# Altium schematic sheet PlanTieV2.SchDoc
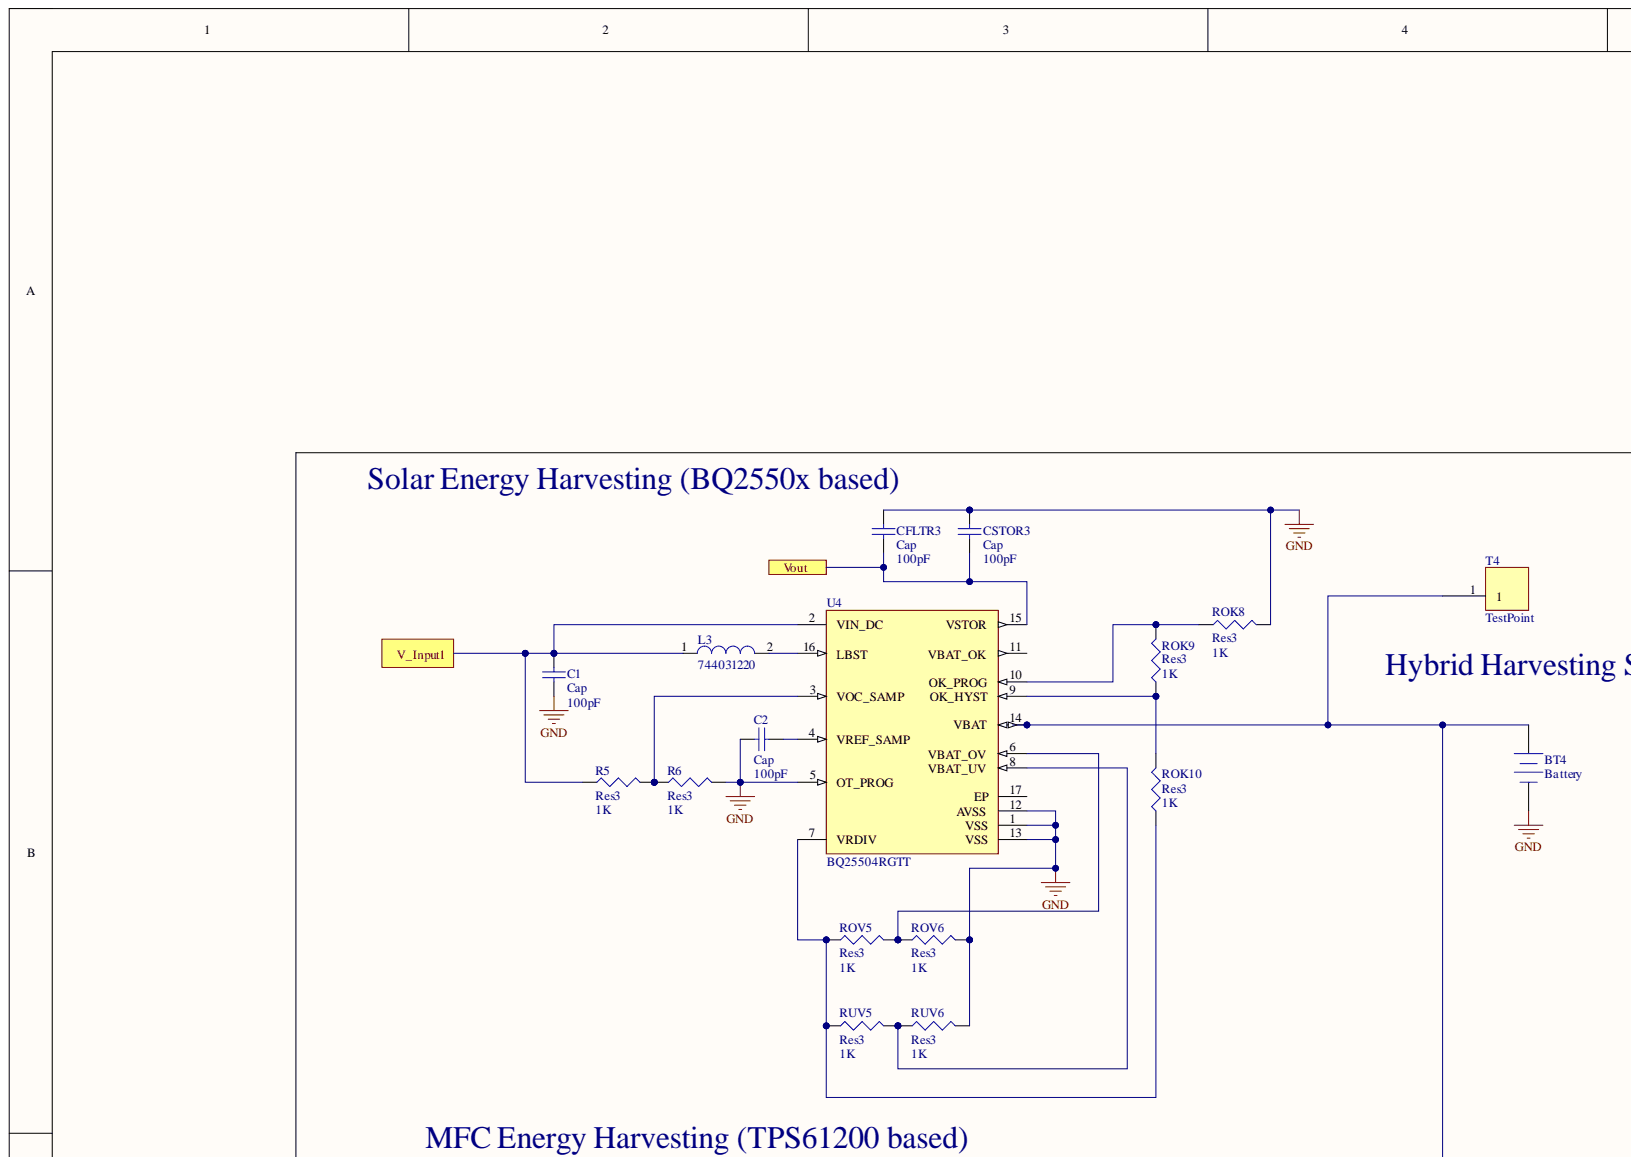
[diagram p0: PlanTieV2.SchDoc, top left]
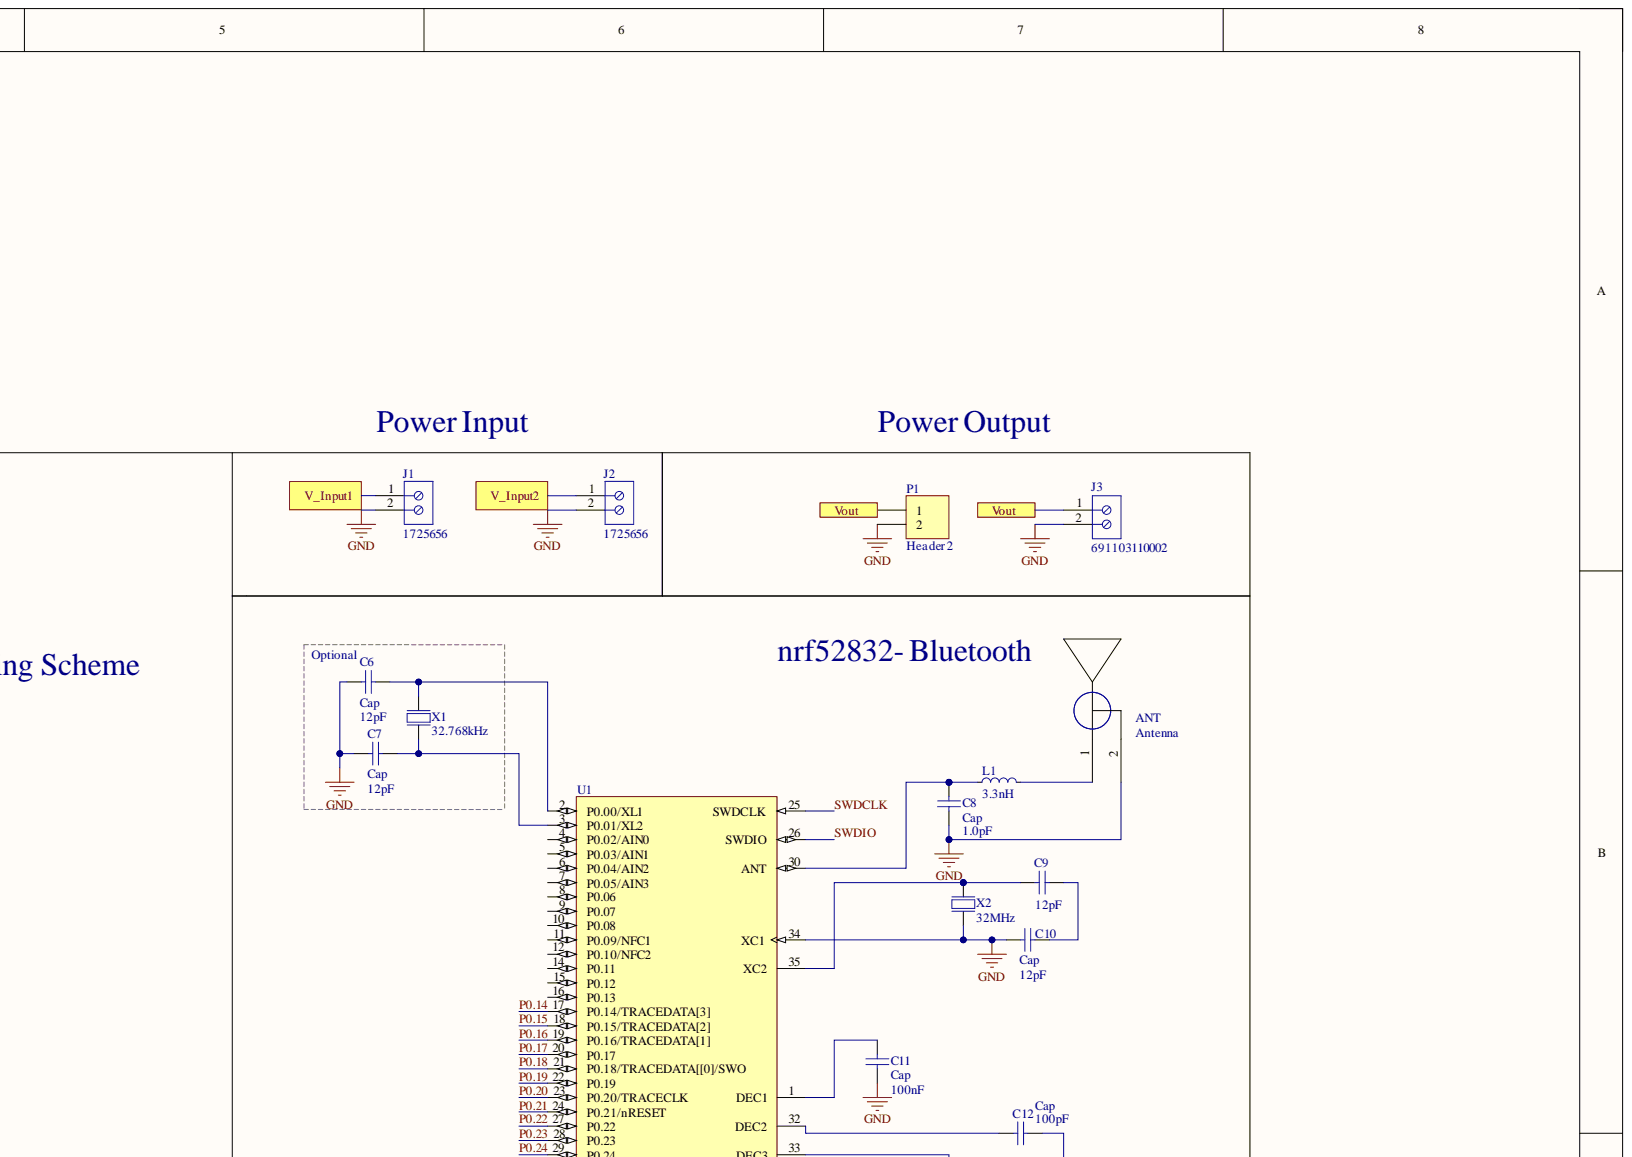
[diagram p1: PlanTieV2.SchDoc, top right]
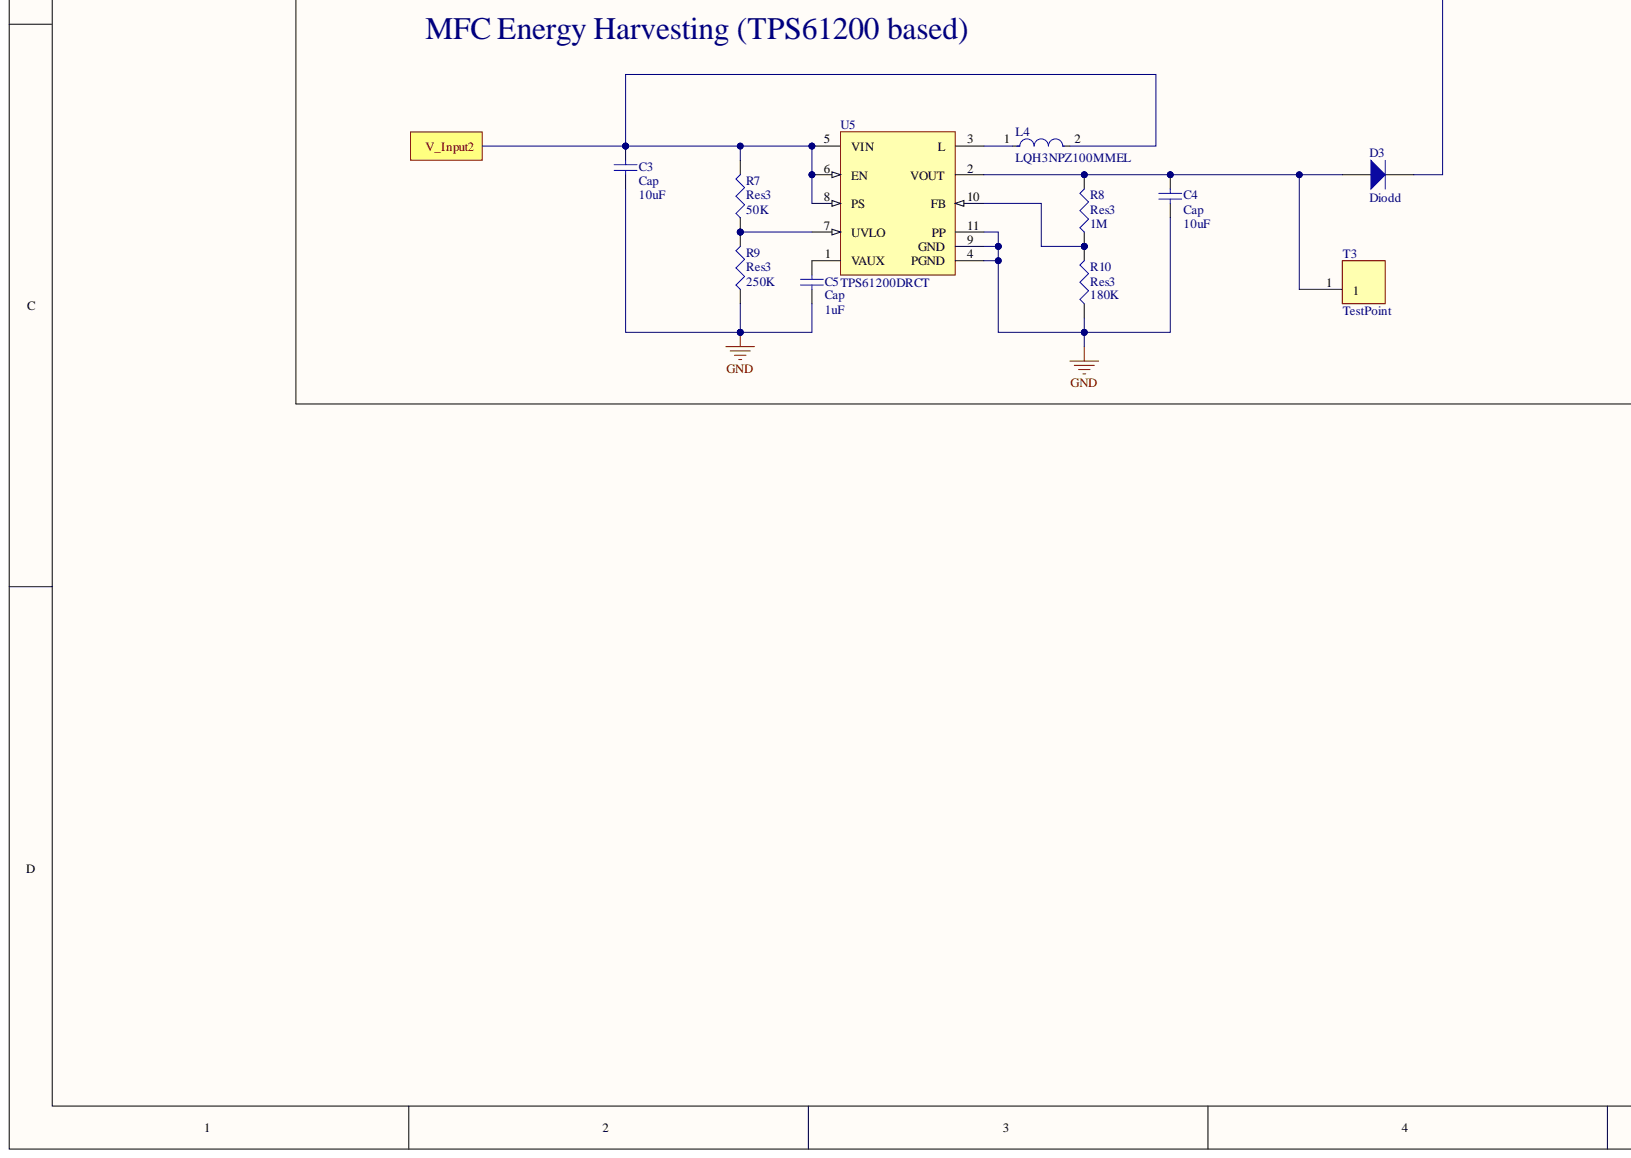
[diagram p2: PlanTieV2.SchDoc, bottom left]
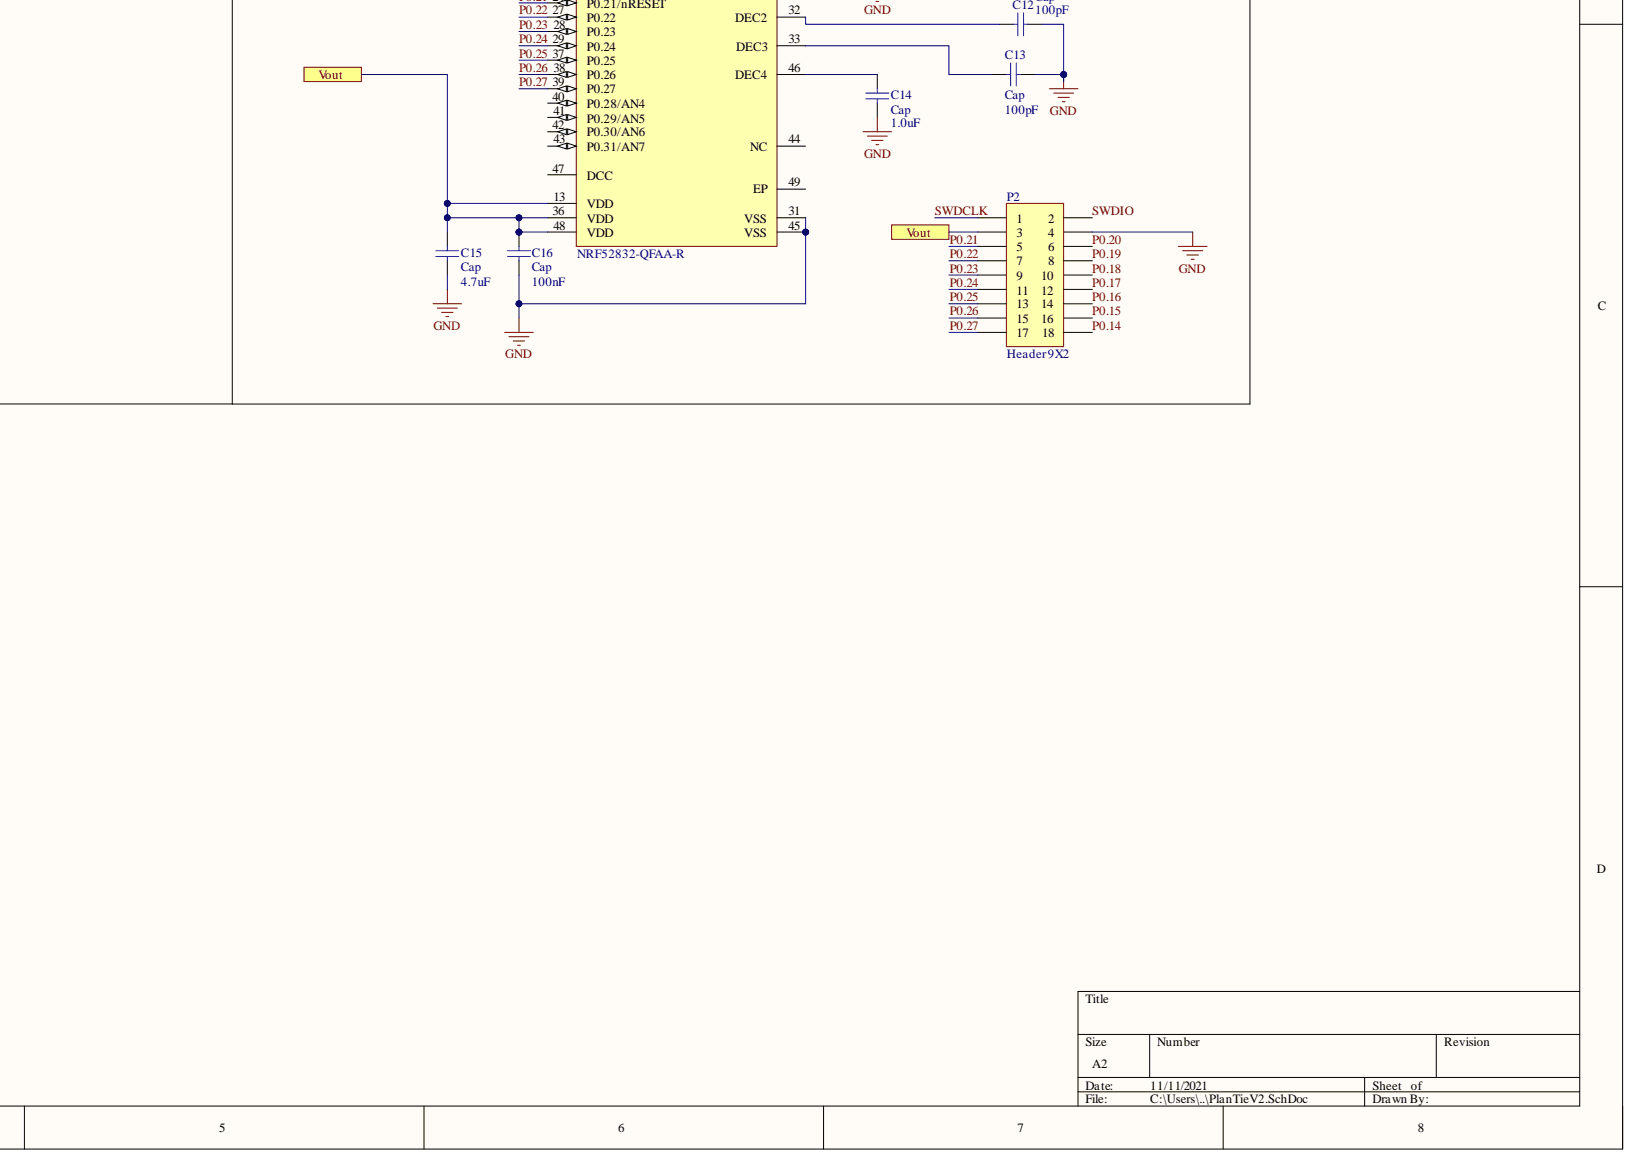
[diagram p3: PlanTieV2.SchDoc, bottom right]

## Parts
ANT  Antenna  [BT-AFN]
BT4  Battery  [BAT-2]
C1  Cap  value 100pF  [1608[0603]]
C2  Cap  value 100pF  [1608[0603]]
C3  Cap  value 10uF  [1608[0603]]
C4  Cap  value 10uF  [1608[0603]]
C5  Cap  value 1uF  [1608[0603]]
C6  Cap  value 12pF  [1608[0603]]
C7  Cap  value 12pF  [1608[0603]]
C8  Cap  value 1.0pF  [1608[0603]]
C9  Cap  value 12pF  [1608[0603]]
C10  Cap  value 12pF  [1608[0603]]
C11  Cap  value 100nF  [1608[0603]]
C12  Cap  value 100pF  [1608[0603]]
C13  Cap  value 100pF  [1608[0603]]
C14  Cap  value 1.0uF  [1608[0603]]
C15  Cap  value 4.7uF  [1608[0603]]
C16  Cap  value 100nF  [1608[0603]]
CFLTR3  Cap  value 100pF  [1608[0603]]
CSTOR3  Cap  value 100pF  [1608[0603]]
D3  Diodd  [DO-41]
J1  1725656  [PHOE-1725656-2]
J2  1725656  [PHOE-1725656-2]
J3  691103110002  [691103110002]
L1  3.3nH  [INDC0603X03L]
L3  744031220  [WE-TPC-3816-S]
L4  LQH3NPZ100MMEL  [FP-LQH3NPH_ME-MFG]
P1  Header 2  [HDR1X2]
P2  Header 9X2  [HDR2X9]
R5  Res3  value 1K  [J1-0603]
R6  Res3  value 1K  [J1-0603]
R7  Res3  value 50K  [J1-0603]
R8  Res3  value 1M  [J1-0603]
R9  Res3  value 250K  [J1-0603]
R10  Res3  value 180K  [J1-0603]
ROK8  Res3  value 1K  [J1-0603]
ROK9  Res3  value 1K  [J1-0603]
ROK10  Res3  value 1K  [J1-0603]
ROV5  Res3  value 1K  [J1-0603]
ROV6  Res3  value 1K  [J1-0603]
RUV5  Res3  value 1K  [J1-0603]
RUV6  Res3  value 1K  [J1-0603]
T3  TestPoint  [PIN1]
T4  TestPoint  [PIN1]
U1  NRF52832-QFAA-R  [NRSC-QF-48_M]
U4  BQ25504RGTT  [RGT0016C_V]
U5  TPS61200DRCT  [DRC0010J_V]
X1  32.768kHz  [XTAL_2012]
X2  32MHz  [BT-XTAL_2016]

## Ports
V_Input1, V_Input2, Vout

## Nets
GND: ANT.2 BT4.2 C1.1 C2.1 C3.1 C4.1 C5.1 C6.2 C7.2 C8.2 C10.2 C11.2 C12.2 C13.1 C14.2 C15.2 C16.2 CFLTR3.2 CSTOR3.2 J1.2 J2.2 J3.2 P1.2 P2.4 R6.2 R9.1 R10.1 ROK8.2 ROV6.2 RUV6.2 U1.31(VSS) U1.34(XC1) U1.45(VSS) U4.1(VSS) U4.5(OT_PROG) U4.12(AVSS) U4.13(VSS) U5.4(PGND) U5.9(GND) U5.11(PP) X2.1 X2.2 X2.4
P0.14: P2.18 U1.17(P0.14/TRACEDATA[3])
P0.15: P2.16 U1.18(P0.15/TRACEDATA[2])
P0.16: P2.14 U1.19(P0.16/TRACEDATA[1])
P0.17: P2.12 U1.20(P0.17)
P0.18: P2.10 U1.21(P0.18/TRACEDATA[[0]/SWO)
P0.19: P2.8 U1.22(P0.19)
P0.20: P2.6 U1.23(P0.20/TRACECLK)
P0.21: P2.5 U1.24(P0.21/nRESET)
P0.22: P2.7 U1.27(P0.22)
P0.23: P2.9 U1.28(P0.23)
P0.24: P2.11 U1.29(P0.24)
P0.25: P2.13 U1.37(P0.25)
P0.26: P2.15 U1.38(P0.26)
P0.27: P2.17 U1.39(P0.27)
SWDCLK: P2.1 U1.25(SWDCLK)
SWDIO: P2.2 U1.26(SWDIO)
V_Input1: C1.2 J1.1 L3.1 R5.1 U4.2(VIN_DC) ; port V_Input1
V_Input2: C3.2 J2.1 L4.2 R7.2 U5.5(VIN) U5.6(EN) U5.8(PS) ; port V_Input2
Vout: C15.1 C16.1 CFLTR3.1 CSTOR3.1 J3.1 P1.1 P2.3 U1.13(VDD) U1.36(VDD) U1.48(VDD) U4.15(VSTOR) ; port Vout
NetANT_1: ANT.1 L1.2
NetBT4_1: BT4.1 D3.2 T4.1 U4.14(VBAT)
NetC2_2: C2.2 U4.4(VREF_SAMP)
NetC4_2: C4.2 D3.1 R8.2 T3.1 U5.2(VOUT)
NetC5_2: C5.2 U5.1(VAUX)
NetC6_1: C6.1 U1.2(P0.00/XL1) X1.1
NetC7_1: C7.1 U1.3(P0.01/XL2) X1.2
NetC8_1: C8.1 L1.1 U1.30(ANT)
NetC9_1: C9.1 C10.1
NetC9_2: C9.2 U1.35(XC2) X2.3
NetC11_1: C11.1 U1.1(DEC1)
NetC12_1: C12.1 U1.32(DEC2)
NetC13_2: C13.2 U1.33(DEC3)
NetC14_1: C14.1 U1.46(DEC4)
NetL3_2: L3.2 U4.16(LBST)
NetL4_1: L4.1 U5.3(L)
NetR5_2: R5.2 R6.1 U4.3(VOC_SAMP)
NetR7_1: R7.1 R9.2 U5.7(UVLO)
NetR8_1: R8.1 R10.2 U5.10(FB)
NetROK8_1: ROK8.1 ROK9.2 U4.10(OK_PROG)
NetROK9_1: ROK9.1 ROK10.2 U4.9(OK_HYST)
NetROK10_1: ROK10.1 ROV5.1 RUV5.1 U4.7(VRDIV)
NetROV5_2: ROV5.2 ROV6.1 U4.6(VBAT_OV)
NetRUV5_2: RUV5.2 RUV6.1 U4.8(VBAT_UV)

Not connected: U1 4(P0.02/AIN0) 5(P0.03/AIN1) 6(P0.04/AIN2) 7(P0.05/AIN3) 8(P0.06) 9(P0.07) 10(P0.08) 11(P0.09/NFC1) 12(P0.10/NFC2) 14(P0.11) 15(P0.12) 16(P0.13) 40(P0.28/AN4) 41(P0.29/AN5) 42(P0.30/AN6) 43(P0.31/AN7) 44(NC) 47(DCC) 49(EP); U4 11(VBAT_OK) 17(EP)

## Library data of the parts
BT4 = Battery: Multicell Battery; Component Kind: Standard; LatestRevisionDate: 17-Jul-2002; LatestRevisionNote: Re-released for DXP Platform.; Library Name: PPi_Hardware.SCHLIB; PackageDescription: Battery; 2 Leads; PackageReference: BAT-2; Published: 24-Mar-1999; Publisher: Altium Limited
C1 C3-C5 C7-C16 CFLTR3 CSTOR3 = Cap: Capacitor; Component Kind: Standard; LatestRevisionDate: 17-Jul-2002; LatestRevisionNote: Re-released for DXP Platform.; Library Name: Miscellaneous Devices.IntLib; PackageDescription: Radial Cap, Thru-Hole; 2 Leads; 0.3 in Pin Spacing; PackageReference: RAD-0.3; Published: 8-Jun-2000; Signal Integrity: Cap; Simulation: CAP; Publisher: Altium Limited
C2 = Cap: Capacitor; Value: 100pF; Component Kind: Standard; LatestRevisionDate: 17-Jul-2002; LatestRevisionNote: Re-released for DXP Platform.; Library Name: Miscellaneous Devices.IntLib; PackageDescription: Radial Cap, Thru-Hole; 2 Leads; 0.3 in Pin Spacing; PackageReference: RAD-0.3; Published: 8-Jun-2000; Signal Integrity: Cap; Simulation: CAP; Publisher: Altium Limited
C6 = Cap: Capacitor; Value: 12pF; Component Kind: Standard; LatestRevisionDate: 17-Jul-2002; LatestRevisionNote: Re-released for DXP Platform.; Library Name: Miscellaneous Devices.IntLib; PackageDescription: Radial Cap, Thru-Hole; 2 Leads; 0.3 in Pin Spacing; PackageReference: RAD-0.3; Published: 8-Jun-2000; Signal Integrity: Cap; Simulation: CAP; Publisher: Altium Limited
D3 = Diode 1N4934: 1 Amp Fast Recovery Rectifier; Code_JEDEC: DO-204-AL; Component Kind: Standard; ComponentLink1Description: Manufacturer Link; ComponentLink1URL: http://www.fairchildsemi.com/; ComponentLink2Description: Datasheet; ComponentLink2URL: http://www.fairchildsemi.com/ds/1N%2F1N4934.pdf; DatasheetVersion: 1999; LatestRevisionDate: 17-Jul-2002; LatestRevisionNote: Re-released for DXP Platform.; Library Name: Miscellaneous Devices.IntLib; PackageDescription: Diode, Axial; Body 5.2 x 2.7 mm (LxDia. max), Lead Dia. 0.86 mm (max); PackageReference: DO-41; PackageVersion: Aug-1999; Published: 8-Jun-2000; Signal Integrity: 1N4934; Simulation: 1N4934; Publisher: Altium Limited
J1 J2 = CMP-2000-05299-1: Male Header, Pitch 2.54 mm, 1 x 2 Position, Height 9 mm, Tail Length 3.5 mm; Manufacturer: Phoenix Contact; Content: 250pc(s); Industrial packaging: Yes; Number of contacts: 2; Pitch: 2.54mm; Colour: Green; Component Kind: Standard; Datasheet URL: http://datasheet.octopart.com/1725656-Phoenix-Contact-datasheet-5302866.pdf; Datasheet Version: Apr-08; Library Name: Altium Content Vault; Manufacturer part No.: 1725656; Manufacturer URL: http://www.phoenixcontact.com/; Type: MPT0,5/2-2,54
J3 = CMP-1502-03254-1: Series 1031 - 3.50 mm Horizontal Entry Modular with Pressure Clamp WR-TBL, 2 pin; Manufacturer: Wurth Elektronik; Pin Number: 2; Pitch: 3.50mm; Component Kind: Standard; Datasheet URL: http://katalog.we-online.de/em/datasheet/6911031100xx.pdf; Library Name: Altium Content Vault; Manufacturer URL: http://www.we-online.de; Mounting Technology: THT; Operating Temperature: -40to105degC; Package Reference: 691103110002; Packaging: Box; Part Number: 691103110002; Publisher: WurthElektronik
L1 = L0201-3n3: High frequency chip inductor ±5%; Artikkelnr Noca: N.A.; Database Table Name: Inductors$; Artikkelnr Simpro: N.A.; Component Kind: Standard; Footprint Doc: 0201; LatestRevisionDate: 2013-04-10; Library Name: Nordic Passive DB.DbLib; Note: High frequency chip inductor ±5%; Part Number: L0201-3n3; Pin Count: 2; Published: 2013-04-10; Value: 3.3nH; Publisher: Nordic Semiconductor
L3 = CMP-0227-00346-1: SMD Shielded Tiny Power Inductor WE-TPC, L = 22.0 µH; Inductance: 22.0 ÂµH; Component Kind: Standard; ComponentLink1Description: Manufacturer Link; ComponentLink1URL: http://www.we-online.com; ComponentLink2Description: Datasheet; ComponentLink2URL: http://www.we-online.de/katalog/media/pdf/744031220.pdf; DatasheetVersion: ver 6, Jan-2009; Library Name: Altium Content Vault; PackageDescription: SMD Inductor, 2-Leads, Body 3.8 x 3.8 mm; PackageReference: WE-TPC-3816-S; PackageVersion: 2009
L4 = CMP-06042-007129-1: Wire Wound Ferrite Inductor for Power Lines For Automotive 10μH ±20% 0.228Ω 1280mA 1212; Current Rating: 1.28A; DC Resistance (DCR): 228mR; Inductance: 10uH; Saturation Current: 810mA; Self Resonant Frequency: 20MHz; Shielding: Shielded; Test Frequency: 1MHz; Case Code (Imperial): 1212; Case Code (Metric): 3030; Case/Package: 1212; Component Kind: Standard; Core Material: Ferrite; Library Name: Altium Content Vault; Max Operating Temperature: 85°C; Min Operating Temperature: -40°C; Mount: Surface Mount; Packaging: Cut Tape; Ratings: AEC-Q200; Resistor Type: Wirewound; Tolerance: 20%
P1 = Header 2: Header, 2-Pin; Component Kind: Standard; LatestRevisionDate: 17-Jul-2002; LatestRevisionNote: Re-released for DXP Platform.; Library Name: Miscellaneous Connectors.IntLib; Signal Integrity: Connector; Publisher: Altium Limited
P2 = Header 9X2: Header, 9-Pin, Dual row; Component Kind: Standard; LatestRevisionDate: 17-Jul-2002; LatestRevisionNote: Re-released for DXP Platform.; Library Name: Miscellaneous Connectors.IntLib; Signal Integrity: Connector; Publisher: Altium Limited
R5-R10 ROK8-ROK10 ROV5 ROV6 RUV5 RUV6 = Res3: Resistor; Component Kind: Standard; LatestRevisionDate: 29-May-2009; LatestRevisionNote: IPC-7351 Footprint Added.; Library Name: Miscellaneous Devices.IntLib; PackageDescription: Chip Resistor; PackageReference: J1-0603; Published: 8-Jun-2000; Signal Integrity: Res; Simulation: RESISTOR; Publisher: Altium Limited
U1 = CMP-2100-03661-1: Multiprotocol Bluetooth Smart, ANT/ANT+ and Proprietary System-on-Chip, 2.4 GHz, 256 KB FLASH, 1.7 to 3.6 V, -40 to 85 degC, 48-Pin QFN, RoHS, Tape and Reel; Manufacturer: Nordic Semiconductor; Frequency: 2.4GHz; Component Kind: Standard; Datasheet URL: http://infocenter.nordicsemi.com/pdf/nRF52832_PS_v1.0.pdf; Datasheet Version: Rev. 1.0, 02/2016; Lead Free: LeadFree; Library Name: Altium Content Vault; Manufacturer URL: http://www.nordicsemi.com/eng/Products/; Max Frequency: 2.4GHz; Max Operating Temperature: 85degC; Min Operating Temperature: -40degC; Mount: SurfaceMount; Package Description: 48-Pin Quad Flat No-lead Package, Body 6 x 6 mm, Pitch 0.4 mm, Thermal Pad 4.6 x 4.6 mm; Package Reference: QF-48; Packaging: TapeandReel; RoHSCompliant: true
U4 = CMP-0321-00258-2: Ultra Low Power Boost Converter with Battery Management for Energy Harvester App, 0.13 V, -40 to 85 degC, 16-pin QFN (RGT), Green (RoHS & no Sb/Br); Manufacturer: Texas Instruments; Lead Acid: N; SubFamily: Battery Charge Management; Vin(Min)(V): 0.13; Code_JEDEC: MO-220; Component Kind: Standard; ComponentLink1Description: Datasheet; ComponentLink1URL: http://www.ti.com/general/docs/lit/getliterature.tsp?genericPartNumber=BQ25504&fileType=pdf; DatasheetVersion: SLUSAH0; Eco-Plan: Green (RoHS & no Sb/Br); Li-Ion: N; Li-Polymer: N; Library Name: Altium Content Vault; Mounting Technology: Surface Mount; NiCd: N; NiMH: N; Over Voltage Protection: N; PackageDescription: 16-Pin Plastic Quad Flat No-Lead, 3 x 3 mm Body, 0.5 mm Pitch, 1.7 x 1.7 mm Exposed Pad; PackageReference: RGT0016C; PackageVersion: revH, Oct-2011; PartNumber: BQ25504RGTT
U5 = CMP-0347-00023-2: Boost Voltage Converter with 0.3 to 5.5 V Input and 1.8 to 5.5 V Output, -40 to 85 degC, 10-Pin SON (DRC), Green (RoHS & no Sb/Br); Manufacturer: Texas Instruments; Regulated Outputs(#): 1; SubFamily: Step-Up Regulator; Switching Frequency(Max)(kHz): 1250; Synchronous Rectification: TRUE; Vin(Max)(V): 5.5; Vin(Min)(V): 0.3; Vout(Min)(V): 1.8; Component Kind: Standard; ComponentLink1Description: Datasheet; ComponentLink1URL: http://www.ti.com/general/docs/lit/getliterature.tsp?genericPartNumber=TPS61200&fileType=pdf; ComponentLink2Description: Package Specification; ComponentLink2URL: http://www.ti.com/litv/pdf/mpds117k; DatasheetVersion: SLVS577B; Eco-Plan: Green (RoHS & no Sb/Br); Library Name: Altium Content Vault; Mounting Technology: Surface Mount; PackageDescription: 10-Pin Plastic Small Outline No-Lead, 3 x 3 x 1 mm Body, 0.5 mm Pitch, Exposed Pad; PackageReference: DRC0010J; PackageVersion: revL, Sep-2011; PartNumber: TPS61200DRCT; Topology: Boost; Vout(Max)(V): 5.5
X1 = XTAL_SMD2012_32.768kHz_9pF_Seiko: XTAL SMD 2012, 32.768kHz, Cl=9pF, Total Tol: ±50ppm; Manufacturer: Seiko; Manufacturer Part Number: SC20S-9.0PF20PPM; Artikkelnr Noca: N.A.; Database Table Name: Crystal_Osc$; Note: XTAL SMD 32.768kHz, 2012; Artikkelnr Simpro: N.A.; Component Kind: Standard; Datasheet: http://www.sii.co.jp/en/quartz/files/2013/03/file_PRODUCT_MASTER_50811_GRAPHIC03.pdf; Footprint Doc: XTAL_2012; LatestRevisionDate: 2015-10-15; Library Name: Nordic Discrete DB.DbLib; Part Number: XTAL_SMD2012_32.768kHz_9pF_Seiko; Pin Count: 2; Published: 2015-10-15; Value: 32.768kHz; Publisher: Nordic Semiconductor
X2 = XTAL_SMD2016_32MHz_8pF_Epson: XTAL SMD 2016, 32MHz, Cl=8pF, Total Tol: ±40ppm; Manufacturer: Epson Toyocom; Manufacturer Part Number: Q22FA12800025; Artikkelnr Noca: N.A.; Database Table Name: Crystal_Osc$; Note: XTAL SMD 32.000MHz; Artikkelnr Simpro: N.A.; Component Kind: Standard; Datasheet: http://www.epsondevice.com/docs/qd/en/DownloadServlet?id=ID000449; Footprint Doc: XTAL_2016; LatestRevisionDate: 2014-11-27; Library Name: Nordic Discrete DB.DbLib; Part Number: XTAL_SMD2016_32MHz_8pF_Epson; Pin Count: 4; Published: 2012-03-09; Supplier: Farnell; Supplier part number: 171-2806; Value: 32MHz; Publisher: Nordic Semiconductor
ANT = Antenna: Component Kind: Standard; Library Name: PPi_Hardware.SCHLIB
T3 T4 = TestPoint: Component Kind: Standard; Library Name: PPi_Hardware.SCHLIB
